# Altium schematic sheet pca20017_power.SchDoc
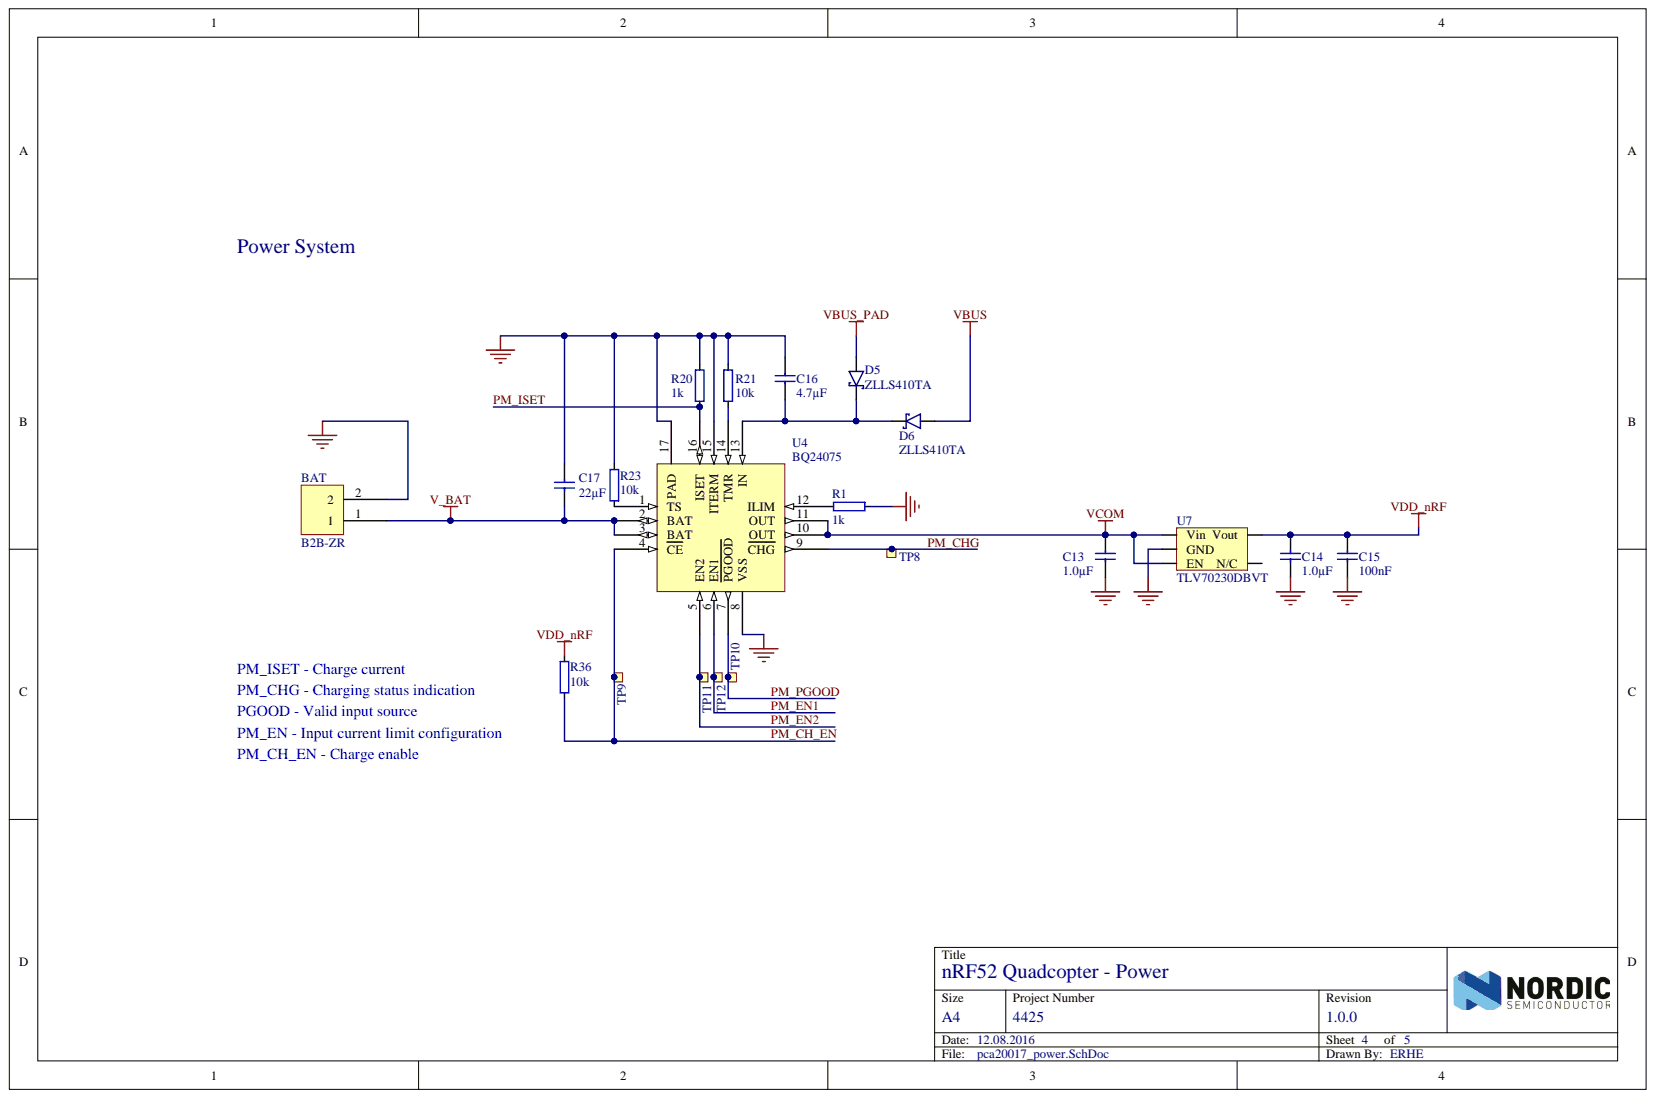
[diagram p0: pca20017_power.SchDoc]

## Parts
BAT  B2B-ZR  [B2B-ZR]
C13  1.0µF  [CAPC1005X04L]
C14  1.0µF  [CAPC1005X04L]
C15  100nF  [CAPC1005X04L]
C16  4.7µF  [CAPC1005X04L]
C17  22µF  [CAPC2012X11L]
D5  ZLLS410TA  [SOD-323]
D6  ZLLS410TA  [SOD-323]
R1  1k  [RESC1005X04L]
R20  1k  [RESC1005X04L]
R21  10k  [RESC1005X04L]
R23  10k  [RESC1005X04L]
R36  10k  [RESC1005X04L]
TP8  Ø1.0mm,SMD  [TP_SMD_Ø1.0mm]  (value not drawn)  (no BOM)
TP9  Ø1.0mm,SMD  [TP_SMD_Ø1.0mm]  (value not drawn)  (no BOM)
TP10  Ø1.0mm,SMD  [TP_SMD_Ø1.0mm]  (value not drawn)  (no BOM)
TP11  Ø1.0mm,SMD  [TP_SMD_Ø1.0mm]  (value not drawn)  (no BOM)
TP12  Ø1.0mm,SMD  [TP_SMD_Ø1.0mm]  (value not drawn)  (no BOM)
U4  BQ24075  [QFN50P300X300X100_HS-17N]
U7  TLV70230DBVT  [SOT-23-5]

## Nets
GND: BAT.2 C13.1 C14.1 C15.1 C16.2 C17.2 R1.2 R20.2 R21.2 R23.1 U4.8(VSS) U4.15(ITERM) U4.17(PAD) U7.2(GND)
V_BAT: BAT.1 C17.1 U4.2(BAT) U4.3(BAT)
VBUS: D6.1
VBUS_PAD: D5.1
VCOM: C13.2 U4.10(OUT) U4.11(OUT) U7.1(Vin) U7.3(EN)
VDD_NRF: C14.2 C15.2 R36.2 U7.5(Vout)
PM_CH_EN: R36.1 TP9.1 U4.4(CE)
PM_CHG: TP8.1 U4.9(CHG)
PM_EN1: TP12.1 U4.6(EN1)
PM_EN2: TP11.1 U4.5(EN2)
PM_ISET: R20.1 U4.16(ISET)
PM_PGOOD: TP10.1 U4.7(PGOOD)
NetC16_1: C16.1 D5.3 D6.3 U4.13(IN)
NetR1_1: R1.1 U4.12(ILIM)
NetR21_1: R21.1 U4.14(TMR)
NetR23_2: R23.2 U4.1(TS)

Not connected: U7 4(N/C)

## Library data of the parts
BAT = B2B-ZR: CONN JST 2POS 0.059" (1.50mm); Manufacturer: JST Sales America Inc; Manufacturer Part Number: B2B-ZR(LF)(SN); Artikkelnr Noca: N.A.; Database Table Name: Connectors$; Artikkelnr Simpro: N.A.; Component Kind: Standard; Datasheet: http://www.jst-mfg.com/product/pdf/eng/eZH.pdf; Footprint Doc: B2B-ZR; LatestRevisionDate: 2015-07-02; Library Name: Nordic Passive DB.DbLib; Part Number: B2B-ZR; Pin Count: 2; Published: 2015-07-02; Supplier: Digi-Key; Supplier part number: 455-1657-ND; Value: B2B-ZR; Publisher: Nordic Semiconductor
C13 C14 = C0402-1µ0: Capacitor, X5R, ±5%; Artikkelnr Noca: 20039235; Artikkelnr Simpro: N.A.; Database Table Name: Capacitors$; Note: 6.3V; Component Kind: Standard; Footprint Doc: 0402; LatestRevisionDate: 2009-11-23; Library Name: Nordic Passive DB.DbLib; Part Number: C0402-1µ0; Pin Count: 2; Published: 2009-05-08; Value: 1.0µF; Publisher: Nordic Semiconductor
C15 = C0402-100n: Capacitor, X7R, ±10%; Artikkelnr Noca: 20039200; Artikkelnr Simpro: N.A.; Database Table Name: Capacitors$; Note: 16V; Component Kind: Standard; Footprint Doc: 0402; LatestRevisionDate: 2009-11-23; Library Name: Nordic Passive DB.DbLib; Part Number: C0402-100n; Pin Count: 2; Published: 2009-05-08; Value: 100nF; Publisher: Nordic Semiconductor
C16 = C0402-4µ7: Capacitor, X5R, ±20%; Artikkelnr Noca: 20039482; Artikkelnr Simpro: N.A.; Database Table Name: Capacitors$; Note: 10V; Component Kind: Standard; Footprint Doc: 0402; LatestRevisionDate: 2016-06-02; Library Name: Nordic Passive DB.DbLib; Part Number: C0402-4µ7; Pin Count: 2; Published: 2009-05-08; Value: 4.7µF; Publisher: Nordic Semiconductor
C17 = C0805-22µ: Capacitor, X5R, ±10%; Artikkelnr Noca: 20039330; Artikkelnr Simpro: N.A.; Database Table Name: Capacitors$; Note: 6.3V; Component Kind: Standard; Footprint Doc: 0805; LatestRevisionDate: 2013-06-04; Library Name: Nordic Passive DB.DbLib; Part Number: C0805-22µ; Pin Count: 2; Published: 2011-01-17; Value: 22µF; Publisher: Nordic Semiconductor
D5 D6 = ZLLS410TA: DIODE SCHOTTKY 10V 0.57A SOD323; 2nd source part number: 522-ZLLS410TA; Manufacturer: Diodes Incorporated; Artikkelnr Noca: N.A.; Database Table Name: Semiconductors$; 2nd source Supplier: Mouser; Artikkelnr Simpro: N.A.; Component Kind: Standard; Datasheet: http://www.diodes.com/datasheets/ZLLS410.pdf; Footprint Doc: SOD-323; LatestRevisionDate: 2014-12-11; Library Name: Nordic Discrete DB.DbLib; Manufacturer Part Number: ZLLS410TA; Part Number: ZLLS410TA; Published: 2014-12-11; Supplier: Digi-Key; Supplier part number: ZLLS410CT-ND; Value: ZLLS410TA; Publisher: Nordic Semiconductor
R1 R20 = R0402-1k0: Resistor, ±1%, 0.063W; Artikkelnr Noca: 27049073; Artikkelnr Simpro: N.A.; Database Table Name: Resistors$; Note: Chip Res 1%; Value Ohm: 1000; Component Kind: Standard; Footprint Doc: 0402; LatestRevisionDate: 2009-11-23; Library Name: Nordic Passive DB.DbLib; Part Number: R0402-1k0; Pin Count: 2; Published: 2009-05-08; Supplier: Farnell; Supplier part number: 173-8850; Value: 1k; Publisher: Nordic Semiconductor
R21 R23 R36 = R0402-10k: Resistor, ±1%, 0.063W; Artikkelnr Noca: 27049097; Artikkelnr Simpro: N.A.; Database Table Name: Resistors$; Note: Chip Res 1%; Value Ohm: 10000; Component Kind: Standard; Footprint Doc: 0402; LatestRevisionDate: 2009-11-23; Library Name: Nordic Passive DB.DbLib; Part Number: R0402-10k; Pin Count: 2; Published: 2009-05-08; Supplier: Farnell; Supplier part number: 173-8864; Value: 10k; Publisher: Nordic Semiconductor
TP8-TP12 = TP_SMD_Ø1.0MM: 1.0mm circular SMD testpad; Manufacturer: Nordic Semiconductor; Artikkelnr Noca: N.A.; Database Table Name: Connectors$; Artikkelnr Simpro: N.A.; Footprint Doc: TP_SMD_Ø1.0mm; LatestRevisionDate: 2013-06-11; Library Name: Nordic Passive DB.DbLib; Part Number: TP_SMD_Ø1.0MM; Pin Count: 1; Published: 2013-06-11; Value: Ø1.0mm,SMD; Publisher: Nordic Semiconductor
U4 = BQ24075: IC SINGLE CELL USB LI-ION CHARGER; Manufacturer: Texas Instruments; Manufacturer Part Number: BQ24075RGTT; Artikkelnr Noca: N.A.; Database Table Name: Misc IC$; Note: USB-Friendly Li-Ion Battery Charger and Power-Path Management IC, Vout 5.5V; Artikkelnr Simpro: N.A.; Component Kind: Standard; Datasheet: http://www.ti.com/lit/ds/symlink/bq24075.pdf; Footprint Doc: S-PVQFN-N16; LatestRevisionDate: 2015-06-24; Library Name: Nordic Active DB.DbLib; Part Number: BQ24075; Pin Count: 16; Published: 2015-06-24; Supplier: Digi-Key; Supplier part number: 296-23840-1-ND; Value: BQ24075; Publisher: Nordic Semiconductor
U7 = TLV70230DBVT: IC REG LDO 3V 300MA SOT23-5; Manufacturer: Texas Instruments; Artikkelnr Noca: N.A.; Database Table Name: Misc IC$; Note: 300-mA, Low-IQ, Low-Dropout Regulator; Artikkelnr Simpro: N.A.; Component Kind: Standard; Datasheet: http://www.ti.com/lit/ds/symlink/tlv70218.pdf; Footprint Doc: SOT-23-5; LatestRevisionDate: 2015-06-24; Library Name: Nordic Active DB.DbLib; Manufacturer Part Number: TLV70230DBVT; Part Number: TLV70230DBVT; Pin Count: 5; Published: 2015-06-24; Supplier: Digi-Key; Supplier part number: 296-27893-1-ND; Value: TLV70230DBVT; Publisher: Nordic Semiconductor
